# Altium schematic sheet Power management.SchDoc
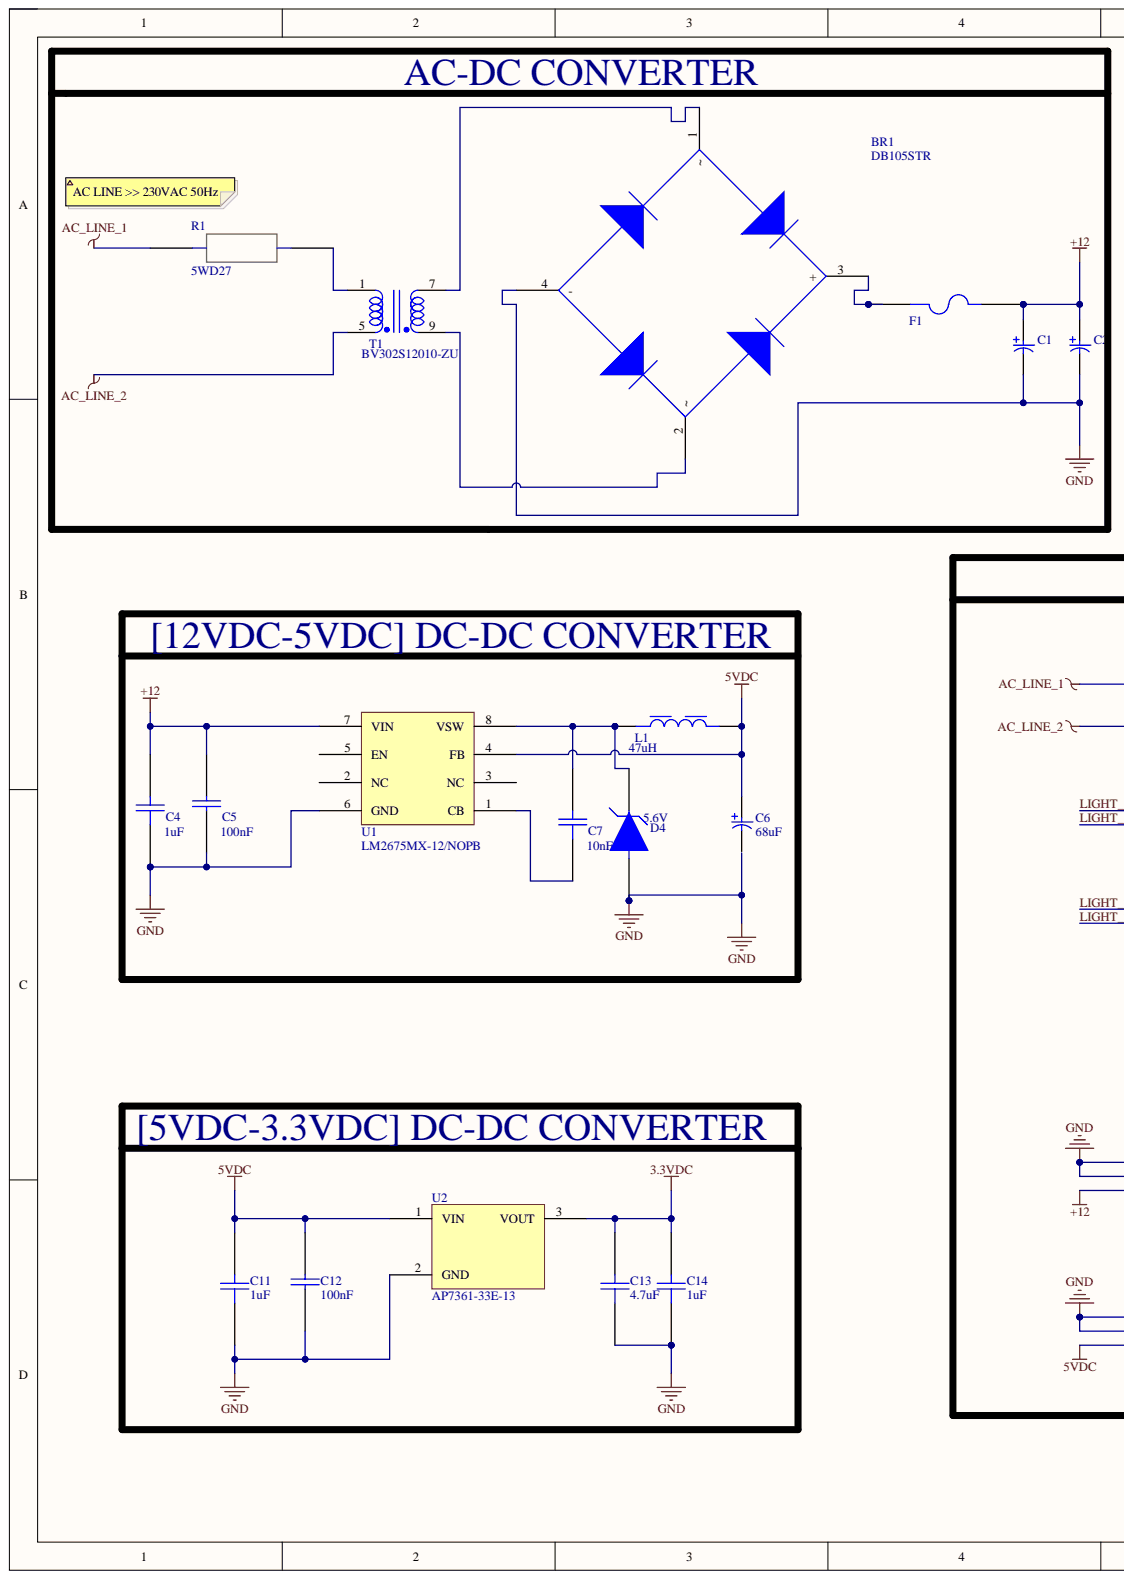
[diagram p0: Power management.SchDoc, left]
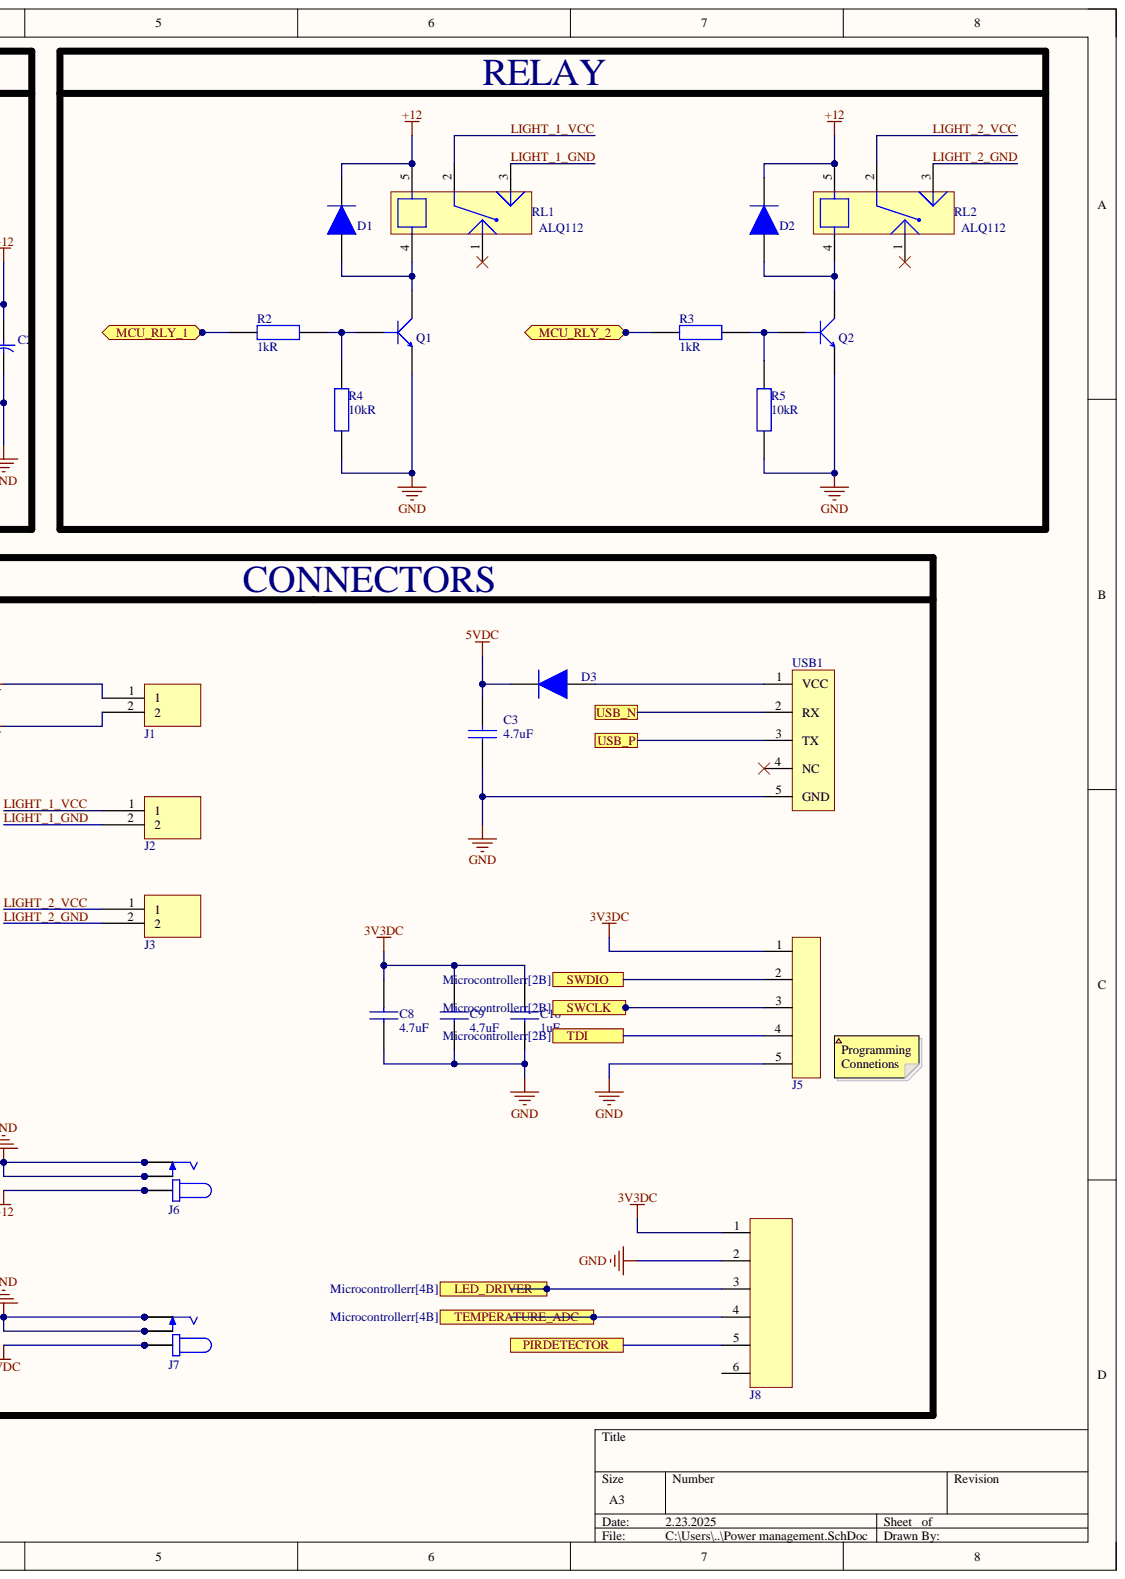
[diagram p1: Power management.SchDoc, right]

## Parts
BR1  DB105STR  [DB105STR]
C1  ESH477M050AH4AA  [CAP_RADIAL_D10_P5]  (value not drawn)
C2  ESH477M050AH4AA  [CAP_RADIAL_D10_P5]  (value not drawn)
C3  Capacitance: 4.7uF  [CAP_0805]  (hidden comment: CL21A475KAQNNNE)
C4  1uF  [CAP_0805]
C5  100nF  [CAP_0805]
C6  value 68uF  [EEV Series]  (hidden comment: EEV686M035A9HAA)
C7  10nF  [CAP_0603]
C8  Capacitance: 4.7uF  [CAP_0805]  (hidden comment: CL21A475KAQNNNE)
C9  Capacitance: 4.7uF  [CAP_0805]  (hidden comment: CL21A475KAQNNNE)
C10  Capacitance: 1uF  [CAP_0805]  (hidden comment: CL21B105KBFNNNE)
C11  value 1uF  [CAP_0805]  (hidden comment: CL21B105KBFNNNE)
C12  value 100nF  [CAP_0603]  (hidden comment: C0603C104K5RACTU)
C13  value 4.7uF  [CAP_0805]  (hidden comment: CL21A475KAQNNNE)
C14  value 1uF  [CAP_0805]  (hidden comment: CL21B105KBFNNNE)
D1  1N4148WS  [SOD323F]  (value not drawn)
D2  1N4148WS  [SOD323F]  (value not drawn)
D3  1N4148WS  [SOD323F]  (value not drawn)
D4  Reference Voltage-Nom: 5.6V  [SMB]  (hidden comment: 1SMB5919BT3G)
F1  3413.0216.22  [3413.0216.22]  (value not drawn)
J1  TBP01R1-508-02BE  [TBP01R1-508]  (value not drawn)
J2  TBP01R1-508-02BE  [TBP01R1-508]  (value not drawn)
J3  TBP01R1-508-02BE  [TBP01R1-508]  (value not drawn)
J5  SMH-105-02-L-S  [PINHEADER1X5_RA]  (value not drawn)
J6  PJ-037AH-SMT-TR  [JACK]  (value not drawn)
J7  PJ-037AH-SMT-TR  [JACK]  (value not drawn)
J8  64800611622  [64800611622]  (value not drawn)
L1  47uH  [IND_1212]
Q1  MMBT3904-7-F  [SOT-23F]  (value not drawn)
Q2  MMBT3904-7-F  [SOT-23F]  (value not drawn)
R1  5WD27  [RES_AXIAL_W]
R2  Resistance: 1kR  [RES_0603]  (hidden comment: RC0603FR-0710KL)
R3  Resistance: 1kR  [RES_0603]  (hidden comment: RC0603FR-0710KL)
R4  Resistance: 10kR  [RES_0603]  (hidden comment: RC0603FR-0710KL)
R5  Resistance: 10kR  [RES_0603]  (hidden comment: RC0603FR-0710KL)
RL1  ALQ112  [ALQ112]
RL2  ALQ112  [ALQ112]
T1  BV302S12010-ZU  [BV3025]
U1  LM2675MX-12/NOPB  [SOIC8]
U2  AP7361-33E-13  [SOT223]
USB1  10118192-0001LF  [MICRO_B_USB]  (value not drawn)

## Ports
LED_DRIVER, MCU_RLY_1, MCU_RLY_2, PIRDETECTOR, SWCLK, SWDIO, TDI, TEMPERATURE_ADC, USB_N, USB_P

## Nets
3.3VDC: C13.2 C14.2 U2.3
3V3DC: C8.2 C9.2 C10.2 J5.1 J8.1
5VDC: C3.2 C6.1 C11.2 C12.2 D3.1 J7.1A J7.1B L1.2 U1.4(FB) U2.1
+12: C1.1 C2.1 C4.2 C5.2 D1.1 D2.1 F1.2 J6.1A J6.1B RL1.5 RL2.5 U1.7(VIN)
AC_LINE_1: J1.1 R1.1
AC_LINE_2: J1.2 T1.5
GND: BR1.4(-) C1.2 C2.2 C3.1 C4.1 C5.1 C8.1 C9.1 C10.1 C11.1 C12.1 C13.1 C14.1 D4.C J5.5 J6.2A J6.2B J6.3A J6.3B J7.2A J7.2B J7.3A J7.3B J8.2 Q1.2 Q2.2 R4.1 R5.1 U1.6(GND) U2.2 USB1.5(GND)
LED_DRIVER: J8.3 ; port LED_DRIVER
LIGHT_1_GND: J2.2 RL1.3
LIGHT_1_VCC: J2.1 RL1.2
LIGHT_2_GND: J3.2 RL2.3
LIGHT_2_VCC: J3.1 RL2.2
MCU_RLY_1: R2.1 ; port MCU_RLY_1
MCU_RLY_2: R3.1 ; port MCU_RLY_2
PIRDETECTOR: J8.5 ; port PIRDETECTOR
SWCLK: J5.3 ; port SWCLK
SWDIO: J5.2 ; port SWDIO
TDI: J5.4 ; port TDI
TEMPERATURE_ADC: J8.4 ; port TEMPERATURE_ADC
USB_N: USB1.2(RX) ; port USB_N
USB_P: USB1.3(TX) ; port USB_P
NetBR1_1: BR1.1(~) T1.7
NetBR1_2: BR1.2(~) T1.9
NetBR1_3: BR1.3(+) F1.1
NetC7_1: C7.1 U1.1(CB)
NetC7_2: C7.2 D4.A L1.1 U1.8(VSW)
NetD1_2: D1.2 Q1.3 RL1.4
NetD2_2: D2.2 Q2.3 RL2.4
NetD3_2: D3.2 USB1.1(VCC)
NetQ1_1: Q1.1 R2.2 R4.2
NetQ2_1: Q2.1 R3.2 R5.2
NetR1_2: R1.2 T1.1

Not connected: C6 2; J8 6; RL1 1; RL2 1; U1 2 3(NC) 5(EN); USB1 4(NC)

## Library data of the parts
BR1 = DB105STR: Bridge Rect 1PHASE 600V 1A Db-s; Manufacturer: SMC; Designed by: ismet Akalın; Case/Package: DB105STR; Category: Industrial Control; Component Kind: Standard; ComponentLink1Description: http://www.smc-diodes.com/propdf/DB101S%20THRU%20DB107S%20N1459%20REV.B.pdf?src-supplier=Digikey; ComponentLink1URL: http://www.smc-diodes.com/propdf/DB101S%20THRU%20DB107S%20N1459%20REV.B.pdf?src-supplier=Digikey; Manufacturer Part Number: DB105STR; Max Current: 1A; Operating Voltage: 600V; Pricing: 1500=0,0542, 4500=0,0528, 7500=0,0521, 15000=0,0513, 30000=0,0499 (USD); RoHS: No; Supplier: Future Electronics; Supplier Part Number: 5119461; Library Name: firstpcblib.IntLib
C1 C2 = CAP ALUM 470 uF %20: CAP ALUM 470UF 20% 50V RADIAL TH; Manufacturer: KEMET; Capacitor Type: Aluminium; Designed by: ismet Akalın; Leakage Current: 235uA; Polarity: Polarized; Ripple Current: 630mA; Tan Delta: 0.12; Category: LDO; Component Kind: Standard; ComponentLink1Description: https://datasheet.ciiva.com:443/pdfs/VipMasterIC/IC/KEME/KEME-S-A0008512286/KEME-S-A0008512286-1.pdf?src-supplier=IHS+Markit; ComponentLink1URL: https://datasheet.ciiva.com:443/pdfs/VipMasterIC/IC/KEME/KEME-S-A0008512286/KEME-S-A0008512286-1.pdf?src-supplier=IHS+Markit; ComponentLink2Description: https://api.kemet.com/component-edge/download/datasheet/ESH477M050AH4AA.pdf?src-supplier=Digikey; ComponentLink2URL: https://api.kemet.com/component-edge/download/datasheet/ESH477M050AH4AA.pdf?src-supplier=Digikey; ComponentLink3Description: https://datasheet.octopart.com/ESH477M050AH4AA-KEMET-datasheet-130075338.pdf?src-supplier=Newark; ComponentLink3URL: https://datasheet.octopart.com/ESH477M050AH4AA-KEMET-datasheet-130075338.pdf?src-supplier=Newark; Dielectric Material: Aluminium; Manufacturer Part Number: ESH477M050AH4AA; Max Operating Temperature: 105°C; Min Operating Temperature: -40°C; Mounting Technology: Through Hole; Package Shape: Cylindrical; Packaging: Bulk; Pins: 2; Pricing: 1=0,61, 10=0,406, 50=0,3188, 200=0,2649, 600=0,2323, 1000=0,21949, 2600=0,19886, 5000=0,18688 (USD); Rated DC Voltage (URdc): 50V; Reach SVHC Compliant: Yes; RoHS: Yes; RoHS Compliant: Yes; Stock: 9084; Supplier: Digikey; Supplier Part Number: 399-15683-ND; Termination: Wire; Tolerance  (fill in as +-): 20%; Tolerance (fill in as +-): 20%; Value: 470uF; Library Name: firstpcblib.IntLib
C3 C8 C9 = CAP CER 4.7uF 0805: CAP CER 4.7uF %10 0805; Manufacturer: Samsung; Designed by: BERK; Dielectric: X5R; Tolerance: 10%; Voltage Rating: 25V; Case Code (Metric): 2012; Case Code (Imperial): 0805; Case/Package: 0805; Category: Ceramic Capacitors; Component Kind: Standard; ComponentLink1Description: https://datasheet.ciiva.com/25798/cl21a475kaqnnne-25798882.pdf?src-supplier=Digi-Key; ComponentLink1URL: https://datasheet.ciiva.com/25798/cl21a475kaqnnne-25798882.pdf?src-supplier=Digi-Key; Construction: Flat; Depth: 1.25mm; Height: 1.25mm; Lead Free: Lead Free; Length: 2mm; Library Name: UDEMY_Library.IntLib; Manufacturer Part Number: CL21A475KAQNNNE; Max Operating Temperature: 85°C; Min Operating Temperature: -55°C; Mount: Surface Mount; Package Quantity: 1; Packaging: Tape and Reel; Pricing 1: 1=0,11000, 10=0,08100, 50=0,04580, 100=0,03800, 500=0,02664, 1000=0,02205 (USD); Radiation Hardening: No; RoHS: Yes; RoHS Compliant: Yes; Series: CL; Stock 1: 1477018; Supplier 1: Digi-Key; Supplier Part Number 1: 1276-1244-1-ND; Thickness: 0.055inch; Voltage Rating (DC): 25V; Width: 0.049inch
C4 = CAP CER 1uF %10 0805: CAP CER 1uF %10 0805 -- CL21B105KBFNNNE; Manufacturer: Samsung; Capacitor Type: Ceramic; Designed by: ismet Akalın; Multilayer: Yes; Rated DC Voltage (URdc): 50V; Temperature Characteristics Code: X7R; Tolerance  (fill in as +-): 10%; Case/Package: 0805; Category: Ceramic Capacitors; Component Kind: Standard; ComponentLink1Description: https://datasheet.ciiva.com:443/pdfs/VipMasterIC/IC/SEMS/SEMS-S-A0008524728/SEMS-S-A0008523023-1.pdf?src-supplier=IHS+Markit; ComponentLink1URL: https://datasheet.ciiva.com:443/pdfs/VipMasterIC/IC/SEMS/SEMS-S-A0008524728/SEMS-S-A0008523023-1.pdf?src-supplier=IHS+Markit; ComponentLink2Description: https://datasheet.ciiva.com/16449/cl21b105kbfnnne-16449003.pdf?src-supplier=Future+Electronics; ComponentLink2URL: https://datasheet.ciiva.com/16449/cl21b105kbfnnne-16449003.pdf?src-supplier=Future+Electronics; ComponentLink3Description: https://datasheet.ciiva.com/25800/cl21b105kbfnnne-25800291.pdf?src-supplier=Digikey; ComponentLink3URL: https://datasheet.ciiva.com/25800/cl21b105kbfnnne-25800291.pdf?src-supplier=Digikey; Dielectric Material: Ceramic; Height: 1.35mm; JESD-609 Code: e3; Length: 2mm; Manufacturer Part Number: CL21B105KBFNNNE; Max Operating Temperature: 125°C; Min Operating Temperature: -55°C; Mounting Technology: Surface Mount; Package Shape: Rectangular; Package Style: SMTMeter; Packaging: Tape and Reel; Pins: 2; Pricing: 1=0,08, 10=0,027, 100=0,0216, 1000=0,0176 (USD); Reach SVHC Compliant: Yes; RoHS: Yes; RoHS Compliant: Yes; Stock: 542767; Supplier: Digikey; Supplier Part Number: 1276-1029-1-ND; Terminal Finish: Nickel; Termination: Wraparound; Tolerance (fill in as +-): 10%; Value: 1uF; Width: 1.25mm; Library Name: firstpcblib.IntLib
C5 = CAP CER 100nF %10 0805: CAP CER 100nF %10 0805 --CL21B104KBCNNNC; Manufacturer: Samsung; Capacitor Type: Ceramic; Designed by: ismet Akalın; Multilayer: Yes; Rated DC Voltage (URdc): 50V; Temperature Characteristics Code: X7R; Tolerance  (fill in as +-): 10%; Case/Package: 0805; Category: Ceramic Capacitors; Component Kind: Standard; ComponentLink1Description: https://datasheet.ciiva.com:443/pdfs/VipMasterIC/IC/SEMS/SEMS-S-A0008521003/SEMS-S-A0008521039-1.pdf?src-supplier=IHS+Markit; ComponentLink1URL: https://datasheet.ciiva.com:443/pdfs/VipMasterIC/IC/SEMS/SEMS-S-A0008521003/SEMS-S-A0008521039-1.pdf?src-supplier=IHS+Markit; ComponentLink2Description: https://datasheet.ciiva.com/25710/cl21b104kbcnnnc-25710182.pdf?src-supplier=Digikey; ComponentLink2URL: https://datasheet.ciiva.com/25710/cl21b104kbcnnnc-25710182.pdf?src-supplier=Digikey; ComponentLink3Description: https://datasheet.ciiva.com/29199/454152-da-01-en-vs-kondensator-0805-29199814.pdf?src-supplier=Conrad; ComponentLink3URL: https://datasheet.ciiva.com/29199/454152-da-01-en-vs-kondensator-0805-29199814.pdf?src-supplier=Conrad; Dielectric Material: Ceramic; Height: 0.95mm; JESD-609 Code: e3; Length: 2mm; Manufacturer Part Number: CL21B104KBCNNNC; Max Operating Temperature: 125°C; Min Operating Temperature: -55°C; Mounting Technology: Surface Mount; Package Shape: Rectangular; Package Style: SMTMeter; Packaging: Tape and Reel; Pins: 2; Pricing: 1=0,08, 10=0,01, 100=0,0088, 1000=0,0064 (USD); Reach SVHC Compliant: Yes; RoHS: Yes; RoHS Compliant: Yes; Stock: 684212; Supplier: Digikey; Supplier Part Number: 1276-1003-1-ND; Terminal Finish: Nickel; Termination: Wraparound; Tolerance (fill in as +-): 10%; Value: 100nF; Width: 1.25mm; Library Name: firstpcblib.IntLib
C6 = CAP ALUM 68uF %20 EEV Series: Manufacturer: KEMET; Capacitor Type: Aluminium; Designed by: ismet Akalın; ESR (Equivalent Series Resistance): 340mR; Leakage Current: 23.8uA; Polarity: Polarized; Rated DC Voltage (URdc): 35V; Ripple Current: 196mA; Tan Delta: 0.12; Tolerance  (fill in as +-): 20%; Case/Package: 2626; Category: Aluminum Electrolytic Capacitors; Component Kind: Standard; ComponentLink1Description: https://datasheet.ciiva.com:443/pdfs/VipMasterIC/IC/KEME/KEME-S-A0008611149/KEME-S-A0008611149-1.pdf?src-supplier=IHS+Markit; ComponentLink1URL: https://datasheet.ciiva.com:443/pdfs/VipMasterIC/IC/KEME/KEME-S-A0008611149/KEME-S-A0008611149-1.pdf?src-supplier=IHS+Markit; ComponentLink2Description: https://datasheet.ciiva.com/5365/kem-a4002-eev-535332-5365784.pdf?src-supplier=Mouser; ComponentLink2URL: https://datasheet.ciiva.com/5365/kem-a4002-eev-535332-5365784.pdf?src-supplier=Mouser; Description: CAP ALUM 68uF %20 EEV Series; Dielectric Material: Aluminium; Height: 7.7mm; Length: 7.8mm; Manufacturer Part Number: EEV686M035A9HAA; Max Operating Temperature: 105°C; Min Operating Temperature: -55°C; Mounting Technology: Surface Mount; Package Shape: Cylindrical; Package Style: SMTMeter; Packaging: Tape and Reel; Pins: 2; Pricing: 1=0,57, 10=0,377, 50=0,295, 100=0,268, 500=0,2189 (USD); Reach SVHC Compliant: Yes; RoHS: Yes; RoHS Compliant: Yes; Stock: 22607; Supplier: Digikey; Supplier Part Number: 399-6722-1-ND; Termination: Flat; Tolerance (fill in as +-): 20%; Width: 6.6mm; Library Name: firstpcblib.IntLib
C7 = CAP CER 10nF %10 0603: CAP CER 10nF %10 0603 0603B103K160CT; Manufacturer: Walsin Technologies; Capacitor Type: Ceramic; Designed by: ismet Akalın; Multilayer: Yes; Rated DC Voltage (URdc): 16V; Temperature Characteristics Code: X7R; Tolerance  (fill in as +-): 10%; Case/Package: 0603; Category: Ceramic Capacitors; Component Kind: Standard; ComponentLink1Description: https://datasheet.ciiva.com:443/pdfs/VipMasterIC/IC/WATC/WATC-S-A0009550342/WATC-S-A0009550342-1.pdf?src-supplier=IHS+Markit; ComponentLink1URL: https://datasheet.ciiva.com:443/pdfs/VipMasterIC/IC/WATC/WATC-S-A0009550342/WATC-S-A0009550342-1.pdf?src-supplier=IHS+Markit; ComponentLink2Description: http://www.farnell.com/datasheets/1958513.pdf?src-supplier=Farnell; ComponentLink2URL: http://www.farnell.com/datasheets/1958513.pdf?src-supplier=Farnell; ComponentLink3Description: https://datasheet.octopart.com/0603B103K160CT-Walsin-Technologies-datasheet-85392065.pdf?src-supplier=Element14; ComponentLink3URL: https://datasheet.octopart.com/0603B103K160CT-Walsin-Technologies-datasheet-85392065.pdf?src-supplier=Element14; ComponentLink4Description: http://www.passivecomponent.com/wp-content/uploads/2018/10/MLCC.pdf?src-supplier=Digikey; ComponentLink4URL: http://www.passivecomponent.com/wp-content/uploads/2018/10/MLCC.pdf?src-supplier=Digikey; ComponentLink5Description: https://datasheet.octopart.com/0603B103K160CT-Walsin-Technologies-datasheet-136037192.pdf?src-supplier=Future+Electronics; ComponentLink5URL: https://datasheet.octopart.com/0603B103K160CT-Walsin-Technologies-datasheet-136037192.pdf?src-supplier=Future+Electronics; ComponentLink6Description: https://datasheet.octopart.com/0603B103K160CT-Walsin-Technologies-datasheet-62066062.pdf?src-supplier=TME; ComponentLink6URL: https://datasheet.octopart.com/0603B103K160CT-Walsin-Technologies-datasheet-62066062.pdf?src-supplier=TME; Dielectric Material: Ceramic; Height: 0.8mm; JESD-609 Code: e3; Length: 1.6mm; Manufacturer Part Number: 0603B103K160CT; Max Operating Temperature: 125°C; Min Operating Temperature: -55°C; Mounting Technology: Surface Mount; Package Shape: Rectangular; Package Style: SMTMeter; Packaging: Tape and Reel; Pins: 2; Pricing: 1=0,1, 10=0,025, 100=0,0146, 1000=0,00737 (USD); Reach SVHC Compliant: Yes; RoHS: Yes; RoHS Compliant: Yes; Stock: 40006; Supplier: Digikey; Supplier Part Number: 1292-1384-1-ND; Terminal Finish: Nickel; Termination: Wraparound; Tolerance (fill in as +-): 10%; Value: 10nF; Width: 0.8mm; Library Name: firstpcblib.IntLib
C10 = CAP CER 1uF 0805: CAP CER 1uF %10 0805; Manufacturer: Samsung; Designed by: BERK; Dielectric: X7R; Tolerance: 10%; Voltage: 50V; Case Code (Metric): 2012; Case Code (Imperial): 0805; Case/Package: 0805; Category: Ceramic Capacitors; Component Kind: Standard; ComponentLink1Description: https://datasheet.ciiva.com/25800/cl21b105kbfnnne-25800291.pdf?src-supplier=Digi-Key; ComponentLink1URL: https://datasheet.ciiva.com/25800/cl21b105kbfnnne-25800291.pdf?src-supplier=Digi-Key; ComponentLink2Description: https://datasheet.ciiva.com/16449/cl21b105kbfnnne-16449003.pdf?src-supplier=Future+Electronics; ComponentLink2URL: https://datasheet.ciiva.com/16449/cl21b105kbfnnne-16449003.pdf?src-supplier=Future+Electronics; ComponentLink3Description: https://datasheet.ciiva.com/26838/getdatasheetpartid-354075-26838668.pdf?src-supplier=Verical; ComponentLink3URL: https://datasheet.ciiva.com/26838/getdatasheetpartid-354075-26838668.pdf?src-supplier=Verical; Construction: Flat; Depth: 1.25mm; Height: 1.25mm; Length: 2mm; Library Name: UDEMY_Library.IntLib; Manufacturer Part Number: CL21B105KBFNNNE; Max Operating Temperature: 125°C; Min Operating Temperature: -55°C; Mount: Surface Mount; Package Quantity: 1; Packaging: Tape and Reel; Pricing 1: 2000=0,02138, 4000=0,01956 (USD); Radiation Hardening: No; RoHS: Yes; RoHS Compliant: Yes; Series: CL; Stock 1: 958000; Supplier 1: Digi-Key; Supplier Part Number 1: 1276-1029-2-ND; Thickness: 0.053inch; Voltage Rating: 50V; Width: 0.049inch
C11 C14 = CAP CER 1uF %10 0805: Manufacturer: Samsung; Capacitor Type: Ceramic; Designed by: ismet Akalın; Multilayer: Yes; Rated DC Voltage (URdc): 50V; Temperature Characteristics Code: X7R; Tolerance  (fill in as +-): 10%; Case/Package: 0805; Category: Ceramic Capacitors; Component Kind: Standard; ComponentLink1Description: https://datasheet.ciiva.com:443/pdfs/VipMasterIC/IC/SEMS/SEMS-S-A0008524728/SEMS-S-A0008523023-1.pdf?src-supplier=IHS+Markit; ComponentLink1URL: https://datasheet.ciiva.com:443/pdfs/VipMasterIC/IC/SEMS/SEMS-S-A0008524728/SEMS-S-A0008523023-1.pdf?src-supplier=IHS+Markit; ComponentLink2Description: https://datasheet.ciiva.com/16449/cl21b105kbfnnne-16449003.pdf?src-supplier=Future+Electronics; ComponentLink2URL: https://datasheet.ciiva.com/16449/cl21b105kbfnnne-16449003.pdf?src-supplier=Future+Electronics; ComponentLink3Description: https://datasheet.ciiva.com/25800/cl21b105kbfnnne-25800291.pdf?src-supplier=Digikey; ComponentLink3URL: https://datasheet.ciiva.com/25800/cl21b105kbfnnne-25800291.pdf?src-supplier=Digikey; Description: CAP CER 1uF %10 0805; Dielectric Material: Ceramic; Height: 1.35mm; JESD-609 Code: e3; Length: 2mm; Manufacturer Part Number: CL21B105KBFNNNE; Max Operating Temperature: 125°C; Min Operating Temperature: -55°C; Mounting Technology: Surface Mount; Package Shape: Rectangular; Package Style: SMTMeter; Packaging: Tape and Reel; Pins: 2; Pricing: 1=0,08, 10=0,027, 100=0,0216, 1000=0,0176 (USD); Reach SVHC Compliant: Yes; RoHS: Yes; RoHS Compliant: Yes; Stock: 542767; Supplier: Digikey; Supplier Part Number: 1276-1029-1-ND; Terminal Finish: Nickel; Termination: Wraparound; Tolerance (fill in as +-): 10%; Width: 1.25mm; Library Name: firstpcblib.IntLib
C12 = CAP CER 100nF 0603: CAP CER 100nF %10 0603 SMD; Manufacturer: KEMET; Capacitor Type: Ceramic; Designed by: ismet Akalın; Multilayer: Yes; Rated DC Voltage (URdc): 50V; Temperature Characteristics Code: X7R; Tolerance  (fill in as +-): 10%; Case/Package: 0603; Category: Ceramic Capacitors; Component Kind: Standard; ComponentLink1Description: https://datasheet.ciiva.com:443/pdfs/VipMasterIC/IC/KEME/KEME-S-A0008991654/KEME-S-A0008991654-1.pdf?src-supplier=IHS+Markit; ComponentLink1URL: https://datasheet.ciiva.com:443/pdfs/VipMasterIC/IC/KEME/KEME-S-A0008991654/KEME-S-A0008991654-1.pdf?src-supplier=IHS+Markit; ComponentLink2Description: https://datasheet.ciiva.com/7823/kem-c1002-x7r-smd-7823591.pdf?src-supplier=Element14; ComponentLink2URL: https://datasheet.ciiva.com/7823/kem-c1002-x7r-smd-7823591.pdf?src-supplier=Element14; ComponentLink3Description: https://datasheet.ciiva.com/14602/70095383-14602583.pdf?src-supplier=Allied+Electronics; ComponentLink3URL: https://datasheet.ciiva.com/14602/70095383-14602583.pdf?src-supplier=Allied+Electronics; Dielectric Material: Ceramic; Height: 0.8mm; JESD-609 Code: e3; Length: 1.6mm; Manufacturer Part Number: C0603C104K5RACTU; Max Operating Temperature: 125°C; Min Operating Temperature: -55°C; Mounting Technology: Surface Mount; Package Shape: Rectangular; Package Style: SMTMeter; Packaging: Tape and Reel; Pins: 2; Pricing: 1=0,08, 10=0,02, 100=0,016, 1000=0,0128 (USD); Reach SVHC Compliant: Yes; RoHS: Yes; RoHS Compliant: Yes; Supplier: Digikey; Supplier Part Number: 399-C0603C104K5RACTUDKR-ND; Terminal Finish: Nickel; Termination: Wraparound; Tolerance (fill in as +-): 10%; Width: 0.8mm; Library Name: firstpcblib.IntLib
C13 = CAP CER 4.7uF %10 0805: Manufacturer: Samsung; Capacitor Type: Ceramic; Designed by: ismet Akalın; Multilayer: Yes; Rated DC Voltage (URdc): 25V; Temperature Characteristics Code: X5R; Tolerance  (fill in as +-): 10%; Case/Package: 0805; Category: Ceramic Capacitors; Component Kind: Standard; ComponentLink1Description: https://datasheet.ciiva.com:443/pdfs/VipMasterIC/IC/SEMS/SEMS-S-A0008528919/SEMS-S-A0008528938-1.pdf?src-supplier=IHS+Markit; ComponentLink1URL: https://datasheet.ciiva.com:443/pdfs/VipMasterIC/IC/SEMS/SEMS-S-A0008528919/SEMS-S-A0008528938-1.pdf?src-supplier=IHS+Markit; ComponentLink2Description: https://datasheet.ciiva.com/25798/cl21a475kaqnnne-25798882.pdf?src-supplier=Digikey; ComponentLink2URL: https://datasheet.ciiva.com/25798/cl21a475kaqnnne-25798882.pdf?src-supplier=Digikey; Description: CAP CER 4.7uF %10 0805; Dielectric Material: Ceramic; Height: 1.4mm; JESD-609 Code: e3; Length: 2mm; Manufacturer Part Number: CL21A475KAQNNNE; Max Operating Temperature: 85°C; Min Operating Temperature: -55°C; Mounting Technology: Surface Mount; Package Shape: Rectangular; Package Style: SMTMeter; Packaging: Tape and Reel; Pins: 2; Pricing: 1=0,1, 10=0,052, 100=0,0335, 1000=0,02212 (USD); Reach SVHC Compliant: Yes; RoHS: Yes; RoHS Compliant: Yes; Stock: 2687030; Supplier: Digikey; Supplier Part Number: 1276-1244-1-ND; Terminal Finish: Nickel; Termination: Wraparound; Tolerance (fill in as +-): 10%; Width: 1.25mm; Library Name: firstpcblib.IntLib
D1-D3 = 1N4148WS: Diode, Single, 75 V, 150 mA, 1 V, 4 ns; Manufacturer: ON Semiconductor / Fairchild; Average Rectified Current: 150mA; Designed by: BERK; Forward Voltage: 1V; Max Forward Surge Current (Ifsm): 2A; Max Repetitive Reverse Voltage (Vrrm): 75V; Max Reverse Current: 5uA; Power Dissipation: 200mW; Recovery Time: 4ns; Category: Rectifier Diodes; Component Kind: Standard; ComponentLink1Description: https://datasheet.ciiva.com/25968/1n914bws-25968945.pdf?src-supplier=Avnet+Europe; ComponentLink1URL: https://datasheet.ciiva.com/25968/1n914bws-25968945.pdf?src-supplier=Avnet+Europe; ComponentLink2Description: https://datasheet.ciiva.com/30479/0900766b810e1cd3-30479206.pdf?src-supplier=RSComponents; ComponentLink2URL: https://datasheet.ciiva.com/30479/0900766b810e1cd3-30479206.pdf?src-supplier=RSComponents; ComponentLink3Description: https://datasheet.ciiva.com/910/1n4148ws-910381.pdf?src-supplier=Digi-Key; ComponentLink3URL: https://datasheet.ciiva.com/910/1n4148ws-910381.pdf?src-supplier=Digi-Key; ComponentLink4Description: https://datasheet.ciiva.com/15208/getdatasheetpartid-127819-15208358.pdf?src-supplier=Verical; ComponentLink4URL: https://datasheet.ciiva.com/15208/getdatasheetpartid-127819-15208358.pdf?src-supplier=Verical; ComponentLink5Description: https://datasheet.ciiva.com/8300/1n914bws-8300360.pdf?src-supplier=Avnet; ComponentLink5URL: https://datasheet.ciiva.com/8300/1n914bws-8300360.pdf?src-supplier=Avnet; ComponentLink6Description: https://datasheet.ciiva.com/910/1n4148ws-910380.pdf?src-supplier=Digi-Key; ComponentLink6URL: https://datasheet.ciiva.com/910/1n4148ws-910380.pdf?src-supplier=Digi-Key; ComponentLink7Description: https://datasheet.ciiva.com/910/1n4148ws-910382.pdf?src-supplier=Digi-Key; ComponentLink7URL: https://datasheet.ciiva.com/910/1n4148ws-910382.pdf?src-supplier=Digi-Key; Contact Plating: Tin,Matte; Element Configuration: Single; Forward Current: 150mA; Height: 1mm; Lead Free: Lead Free; Length: 1.8mm; Library Name: UDEMY_Library.IntLib; Manufacturer Part Number: 1N4148WS; Max Operating Temperature: 150°C; Max Reverse Voltage (DC): 75V; Min Operating Temperature: -55°C; Mount: Surface Mount; Number of Pins: 2; Package Quantity: 1; Packaging: Tape and Reel; Pricing 1: 1=0,17000, 10=0,12100, 100=0,06530, 500=0,05128, 1000=0,03562 (USD); Radiation Hardening: No; REACH SVHC: No SVHC; Reverse Recovery Time: 4ns; Reverse Voltage: 75V; RoHS: Yes; RoHS Compliant: Yes; Series: -; Stock 1: 79118; Supplier 1: Digi-Key; Supplier Part Number 1: 1N4148WSFSCT-ND; Weight: 0.0276g; Width: 1.35mm
D4 = 1SMB5919BT3G: Zener Diode, 5.6V V(Z), 5%, 0.55W, Silicon, Unidirectional, DO-214AA; Manufacturer: ON Semiconductor; Designed by: ismet Akalın; Dynamic Impedance-Max: 2R; Max Power Dissipation: 550mW; Number of Elements: 1; Package Body Material: Plastic; Qualification Status: Not Qualified; Test Current: 66.9mA; Time @ Peak Reflow Temperature-Max (s): 40s; Category: Zener Diodes; Component Kind: Standard; ComponentLink1Description: https://datasheet.ciiva.com:443/pdfs/VipMasterIC/IC/ONSM/ONSM-S-A0001810303/ONSM-S-A0001810303-1.pdf?src-supplier=IHS+Markit; ComponentLink1URL: https://datasheet.ciiva.com:443/pdfs/VipMasterIC/IC/ONSM/ONSM-S-A0001810303/ONSM-S-A0001810303-1.pdf?src-supplier=IHS+Markit; ComponentLink2Description: https://datasheet.ciiva.com/895/1smb5913bt3-d-895203.pdf?src-supplier=Digikey; ComponentLink2URL: https://datasheet.ciiva.com/895/1smb5913bt3-d-895203.pdf?src-supplier=Digikey; ComponentLink3Description: https://datasheet.ciiva.com/895/1smb5913bt3-d-895204.pdf?src-supplier=Digikey; ComponentLink3URL: https://datasheet.ciiva.com/895/1smb5913bt3-d-895204.pdf?src-supplier=Digikey; ComponentLink4Description: https://datasheet.ciiva.com/895/1smb5913bt3-d-895205.pdf?src-supplier=Digikey; ComponentLink4URL: https://datasheet.ciiva.com/895/1smb5913bt3-d-895205.pdf?src-supplier=Digikey; Diode Element Material: SILICON; Diode Type: ZENER; JEDEC-95 Code: DO-214AA; JESD-609 Code: e3; Manufacturer Part Number: 1SMB5919BT3G; Max Operating Temperature: 150°C; Min Operating Temperature: -65°C; Moisture Sensitivity Level: 1; Package Shape: Rectangular; Package Style: SMALL OUTLINEMeter; Peak Reflow Temperature (Cel): 260°C; Pins: 2; Polarity: Unidirectional; Pricing: 2500=0,0735 (USD); Reach SVHC Compliant: No; RoHS: Yes; RoHS Compliant: Yes; Stock: 2500; Supplier: Digikey; Supplier Part Number: 1SMB5919BT3GOSTR-ND; Surface Mount: Yes; Technology: ZENER; Terminal Finish: Tin; Terminal Form: L BEND; Terminal Position: DUAL; Voltage Tol-Max: 5%; Library Name: firstpcblib.IntLib
F1 = 3413.0216.22: Electric Fuse, Very Fast Blow, 1A, 32VAC, 63VDC, 63A (IR), Surface Mount, 1206; Manufacturer: Schurter; Body Breadth: 1.6mm; Body Height: 0.6mm; Body Length or Diameter: 3.2mm; Designed by: ismet Akalın; Joule-integral-Nom: 0.2J; Pre-arcing time-Min: 1ms; Reference Standard: UL; Voltage Rating: 32V; Blow Characteristic: VERY FAST; Category: Fuses; Circuit Protection Type: Electric Fuse; Component Kind: Standard; ComponentLink1Description: https://datasheet.ciiva.com:443/pdfs/VipMasterIC/IC/SHRI/SHRI-S-A0004985783/SHRI-S-A0004985783-1.pdf?src-supplier=IHS+Markit; ComponentLink1URL: https://datasheet.ciiva.com:443/pdfs/VipMasterIC/IC/SHRI/SHRI-S-A0004985783/SHRI-S-A0004985783-1.pdf?src-supplier=IHS+Markit; ComponentLink2Description: https://datasheet.ciiva.com/301/typ-usi-1206-301440.pdf?src-supplier=Digikey; ComponentLink2URL: https://datasheet.ciiva.com/301/typ-usi-1206-301440.pdf?src-supplier=Digikey; ComponentLink3Description: https://datasheet.ciiva.com/14102/qousi1206-data-e-14102903.pdf?src-supplier=Distrelec; ComponentLink3URL: https://datasheet.ciiva.com/14102/qousi1206-data-e-14102903.pdf?src-supplier=Distrelec; ComponentLink4Description: https://datasheet.ciiva.com/8264/typ-usi-1206-8264583.pdf?src-supplier=Avnet; ComponentLink4URL: https://datasheet.ciiva.com/8264/typ-usi-1206-8264583.pdf?src-supplier=Avnet; ComponentLink5Description: https://datasheet.ciiva.com/16316/typ-usi-1206-16316588.pdf?src-supplier=Future+Electronics; ComponentLink5URL: https://datasheet.ciiva.com/16316/typ-usi-1206-16316588.pdf?src-supplier=Future+Electronics; ComponentLink6Description: https://datasheet.ciiva.com/301/typ-usi-1206-301439.pdf?src-supplier=Digikey; ComponentLink6URL: https://datasheet.ciiva.com/301/typ-usi-1206-301439.pdf?src-supplier=Digikey; ComponentLink7Description: https://datasheet.ciiva.com/301/typ-usi-1206-301441.pdf?src-supplier=Digikey; ComponentLink7URL: https://datasheet.ciiva.com/301/typ-usi-1206-301441.pdf?src-supplier=Digikey; ComponentLink8Description: https://datasheet.ciiva.com/14541/70160006-14541114.pdf?src-supplier=Allied+Electronics; ComponentLink8URL: https://datasheet.ciiva.com/14541/70160006-14541114.pdf?src-supplier=Allied+Electronics; Current Rating: 1A; JESD-609 Code: e4; Manufacturer Part Number: 3413.0216.22; Max Operating Temperature: 90°C; Min Operating Temperature: -55°C; Mounting Technology: Surface Mount; Packaging: Tape and Reel; Pricing: 1=0,67, 50=0,524, 100=0,397, 500=0,3625 (USD); Rated Breaking Capacity: 63A; Reach SVHC Compliant: Yes; RoHS: Yes; RoHS Compliant: Yes; Stock: 13626; Supplier: Digikey; Supplier Part Number: 486-1144-1-ND; Terminal Finish: Nickel; Termination: Wraparound; Library Name: firstpcblib.IntLib
J1-J3 = TBP01R1-508-02BE: Strip Terminal Block; Manufacturer: CUI Devices; Designed by: ismet Akalın; Category: Terminal Blocks; Component Kind: Standard; ComponentLink1Description: https://www.cuidevices.com/product/resource/digikeypdf/tbp01r1-508.pdf?src-supplier=Digikey; ComponentLink1URL: https://www.cuidevices.com/product/resource/digikeypdf/tbp01r1-508.pdf?src-supplier=Digikey; ComponentLink2Description: https://datasheet.octopart.com/TBP01R1-508-02BE-CUI-Devices-datasheet-147843976.pdf?src-supplier=Future+Electronics; ComponentLink2URL: https://datasheet.octopart.com/TBP01R1-508-02BE-CUI-Devices-datasheet-147843976.pdf?src-supplier=Future+Electronics; ComponentLink3Description: https://datasheet.octopart.com/TBP01R1-508-02BE-CUI-Devices-datasheet-154730754.pdf?src-supplier=Electro+Sonic; ComponentLink3URL: https://datasheet.octopart.com/TBP01R1-508-02BE-CUI-Devices-datasheet-154730754.pdf?src-supplier=Electro+Sonic; Manufacturer Part Number: TBP01R1-508-02BE; Pricing: 1=0,22, 10=0,17, 25=0,1496, 50=0,1346, 100=0,1213, 400=0,0995, 800=0,09115, 1200=0,08698, 5200=0,0775 (USD); RoHS: Yes; Stock: 91901; Supplier: Digikey; Supplier Part Number: 102-6406-ND; Library Name: firstpcblib.IntLib
J5 = SMH-105-02-L-S: .100 C.L. HORIZONTAL SMT SOCKET; Manufacturer: Samtec; Contact Resistance: 10mR; Designed by: BERK; Gender: Receptacle; Number of Contacts: 4; Pitch: 2.54mm; Category: Headers and Wire Housings; Component Kind: Standard; ComponentLink1Description: http://components.arrow.com/part/dataview/0323_04802604?src-supplier=Arrow+Europe; ComponentLink1URL: http://components.arrow.com/part/dataview/0323_04802604?src-supplier=Arrow+Europe; ComponentLink2Description: http://www.farnell.com/datasheets/1927708.pdf?_ga=2.237598468.569325269.1503285096-1413671594.1503025027&src-supplier=Farnell; ComponentLink2URL: http://www.farnell.com/datasheets/1927708.pdf?_ga=2.237598468.569325269.1503285096-1413671594.1503025027&src-supplier=Farnell; ComponentLink3Description: ftp://ftp.samtec.com/webfiles/PDF/SMH.PDF?src-supplier=Avnet+Europe; ComponentLink3URL: ftp://ftp.samtec.com/webfiles/PDF/SMH.PDF?src-supplier=Avnet+Europe; ComponentLink4Description: http://www.samtec.com/documents/webfiles/pdf/SMH.PDF?src-supplier=Newark; ComponentLink4URL: http://www.samtec.com/documents/webfiles/pdf/SMH.PDF?src-supplier=Newark; ComponentLink5Description: http://www.samtec.com/documents/webfiles/pdf/SMH.PDF?src-supplier=Avnet; ComponentLink5URL: http://www.samtec.com/documents/webfiles/pdf/SMH.PDF?src-supplier=Avnet; ComponentLink6Description: http://suddendocs.samtec.com/catalog_english/smh.pdf?src-supplier=Digi-Key; ComponentLink6URL: http://suddendocs.samtec.com/catalog_english/smh.pdf?src-supplier=Digi-Key; Contact Material: Bronze; Contact Plating: Gold; Depth: 10.8mm; Housing Color: Black; Housing Material: Polymer; Length: 13.21mm; Library Name: UDEMY_Library.IntLib; Manufacturer Part Number: SMH-105-02-L-S; Max Operating Temperature: 125°C; Min Operating Temperature: -55°C; Number of Rows: 1; Orientation: Horizontal; Packaging: Rail/Tube; Pricing 1: 1=1,40000, 10=1,27400, 100=1,09200, 500=0,91000, 1000=0,78000, 5000=0,69160, 10000=0,67600 (USD); Radiation Hardening: No; REACH SVHC: No SVHC; RoHS: Yes; Stock 1: 59; Supplier 1: Digi-Key; Supplier Part Number 1: SAM11813-ND; Termination: Solder
J6 J7 = PJ-037AH-SMT-TR: Power Barrel Connector Jack 2.10mm ID (0.083"), 5.50mm OD (0.217") Surface Mount, Right Angle; Through Hole; Manufacturer: CUI; Designed by: ismet Akalın; Category: Uncategorized; Component Kind: Standard; Manufacturer Part Number: PJ-037AH-SMT-TR; Library Name: firstpcblib.IntLib
J8 = 64800611622_conn_6pin: 1.50mm 6PIN Connector; Manufacturer: Wurth Electronics; Component Kind: Standard; Library Name: UDEMY_Library.IntLib; Manufacturer Part Number: 64800611622; Pricing 1: 1000=0,29900, 2000=0,28200 (GBP); RoHS: No; Stock 1: 100; Supplier 1: RSComponents; Supplier Part Number 1: 2138726
L1 = 78438335470: FIXED IND 47UH 390MA 2.3 OHM SMD 78438335470; Manufacturer: Wurth Electronics; Designed by: ismet Akalın; Inductor Type: GENERAL PURPOSE INDUCTOR; Category: Fixed Inductors; Component Kind: Standard; ComponentLink1Description: https://datasheet.ciiva.com:443/pdfs/VipMasterIC/IC/MIDC/MIDC-S-A0004708317/MIDC-S-A0004708317-1.pdf?src-supplier=IHS+Markit; ComponentLink1URL: https://datasheet.ciiva.com:443/pdfs/VipMasterIC/IC/MIDC/MIDC-S-A0004708317/MIDC-S-A0004708317-1.pdf?src-supplier=IHS+Markit; ComponentLink2Description: https://media.digikey.com/pdf/Data%20Sheets/Wurth%20Electronics%20PDFs/Q+_Products_Flyer.pdf?src-supplier=Digikey; ComponentLink2URL: https://media.digikey.com/pdf/Data%20Sheets/Wurth%20Electronics%20PDFs/Q+_Products_Flyer.pdf?src-supplier=Digikey; Manufacturer Part Number: 78438335470; Pricing: 1=1,9, 50=1,773, 250=1,62272, 500=1,5048 (USD); Reach SVHC Compliant: Yes; RoHS: Yes; RoHS Compliant: No; Stock: 5404; Supplier: Digikey; Supplier Part Number: 732-6947-1-ND; Library Name: firstpcblib.IntLib
Q1 Q2 = MMBT3904-7-F: Transistor General Purpose BJT NPN 40 Volt 0.2A Automotive 3-Pin SOT-23; Manufacturer: Diodes Zetex; Collector Base Voltage (VCBO): 60V; Collector Emitter Breakdown Voltage: 40V; Collector Emitter Saturation Voltage: 300mV; Continuous Collector Current: 200mA; Designed by: BERK; Element Configuration: Single; Emitter Base Voltage (VEBO): 6V; Frequency: 300MHz; hFE Min: 100; Max Power Dissipation: 300mW; Number of Elements: 1; Power Dissipation: 350mW; Case/Package: SOT-23; Category: BJTs; Collector Emitter Voltage (VCEO): 40V; Collector-emitter Voltage-Max: 300mV; Component Kind: Standard; ComponentLink1Description: https://datasheet.ciiva.com/11201/ds30036-11201778.pdf?src-supplier=Avnet; ComponentLink1URL: https://datasheet.ciiva.com/11201/ds30036-11201778.pdf?src-supplier=Avnet; ComponentLink2Description: https://datasheet.ciiva.com/15138/getdatasheetpartid-53265-15138780.pdf?src-supplier=Verical; ComponentLink2URL: https://datasheet.ciiva.com/15138/getdatasheetpartid-53265-15138780.pdf?src-supplier=Verical; ComponentLink3Description: https://datasheet.ciiva.com/6361/ds30036-6361254.pdf?src-supplier=Digi-Key; ComponentLink3URL: https://datasheet.ciiva.com/6361/ds30036-6361254.pdf?src-supplier=Digi-Key; ComponentLink4Description: https://datasheet.ciiva.com/22711/1915516-22711750.pdf?src-supplier=Element14; ComponentLink4URL: https://datasheet.ciiva.com/22711/1915516-22711750.pdf?src-supplier=Element14; ComponentLink5Description: https://datasheet.ciiva.com/11198/ds30036-11198999.pdf?src-supplier=Avnet; ComponentLink5URL: https://datasheet.ciiva.com/11198/ds30036-11198999.pdf?src-supplier=Avnet; ComponentLink6Description: https://datasheet.ciiva.com/6361/ds30036-6361256.pdf?src-supplier=Digi-Key; ComponentLink6URL: https://datasheet.ciiva.com/6361/ds30036-6361256.pdf?src-supplier=Digi-Key; Contact Plating: Tin,Matte; Gain Bandwidth Product: 300MHz; Height: 1mm; Lead Free: Lead Free; Length: 3.05mm; Library Name: UDEMY_Library.IntLib; Manufacturer Part Number: MMBT3904-7-F; Max Breakdown Voltage: 40V; Max Collector Current: 200mA; Max Frequency: 300MHz; Max Operating Temperature: 150°C; Min Operating Temperature: -55°C; Mount: Surface Mount; Number of Pins: 3; Package Quantity: 3000; Packaging: Tape and Reel; Polarity: NPN; Pricing 1: 1=0,12000, 10=0,08600, 25=0,08280, 100=0,04660, 500=0,03664, 1000=0,02544 (USD); Radiation Hardening: No; REACH SVHC: No SVHC; RoHS: Yes; RoHS Compliant: Yes; Series: -; Stock 1: 2393281; Supplier 1: Digi-Key; Supplier Part Number 1: MMBT3904-FDIDKR-ND; Transition Frequency: 300MHz; Voltage Rating (DC): 40V; Weight: 0.000282oz; Width: 1.4mm
R1 = 5WD27: Fixed Resistor, Wire Wound, 5W, 0.27ohm, 200V, 5% +/-Tol, 300ppm/Cel, 8738; Manufacturer: NTE Electronics; Designed by: ismet Akalın; Lead Diameter: 0.8mm; Lead Length: 38.1mm; Package Height: 9.65mm; Package Length: 22mm; Temperature Coefficient (ppm/Cel): 300; Value: 270mR; Case/Package: 8738; Category: Through-Hole Resistors; Component Kind: Standard; Construction: Wirewound; Manufacturer Part Number: 5WD27; Package Style: AXIAL; Package Width: 9.65mm; Pins: 2; Power: 5W; Resistor Type: FIXED RESISTOR; RoHS Compliant: Yes; Technology: WIRE WOUND; Tolerance: 5%; Working Voltage: 200V; Library Name: firstpcblib.IntLib
R2 R3 = RES 1K OHM 0603: RES 1K %1 OHM 0603 SMD; Manufacturer: Yageo; Manufacturer Part Number: RC0603JR-071KL; Body Length: 1.6mm; Designed by: BERK; Power Rating: 100mW; Resistance Tolerance: 5%; Temperature Coefficient: 100ppm/°C; Voltage Rating: 50V; Case Code (Metric): 1608; Case Code (Imperial): 0603; Case/Package: 0603; Category: Chip SMD Resistors; Component Kind: Standard; ComponentLink1Description: https://datasheet.ciiva.com/8883/pyu-rc0603-51-rohs-l-4-8883051.pdf?src-supplier=Avnet; ComponentLink1URL: https://datasheet.ciiva.com/8883/pyu-rc0603-51-rohs-l-4-8883051.pdf?src-supplier=Avnet; ComponentLink2Description: https://datasheet.ciiva.com/7746/443971-7746461.pdf?src-supplier=Element14; ComponentLink2URL: https://datasheet.ciiva.com/7746/443971-7746461.pdf?src-supplier=Element14; ComponentLink3Description: https://datasheet.ciiva.com/22747/443971-22747533.pdf?src-supplier=Element14; ComponentLink3URL: https://datasheet.ciiva.com/22747/443971-22747533.pdf?src-supplier=Element14; ComponentLink4Description: https://datasheet.ciiva.com/7746/443971-7746462.pdf?src-supplier=Element14; ComponentLink4URL: https://datasheet.ciiva.com/7746/443971-7746462.pdf?src-supplier=Element14; Construction: Rectangular; Contact Plating: Tin,Matte; Depth: 0.8mm; Height: 0.45mm; Lead Free: Lead Free; Length: 1.6mm; Library Name: UDEMY_Library.IntLib; Max Operating Temperature: 155°C; Military Standard: Not; Min Operating Temperature: -55°C; Number of Pins: 2; Package Quantity: 5000; Packaging: Tape and Reel; Pricing 1: 1=0,10000, 10=0,01900, 100=0,00770, 1000=0,00344, 2500=0,00298 (USD); Radiation Hardening: No; REACH SVHC: Unknown; Resistor Type: Thick Film; RoHS: Yes; RoHS Compliant: Yes; Series: RC; Size Code: 0603; Stock 1: 5112056; Supplier 1: Digi-Key; Supplier Part Number 1: 311-1.0KGRCT-ND; Termination: SMD/SMT; Tolerance: 5%; Voltage Rating (DC): 50V; Width: 0.8mm
R4 R5 = RES 10K OHM 0603: RES 10K %1 OHM 0603 SMD; Manufacturer: Yageo; Designed by: BERK; Max Power Dissipation: 100mW; Resistance Tolerance: 1%; Temperature Coefficient: 100ppm/°C; Voltage Rating: 75V; Voltage Rating (DC): 50V; Case Code (Metric): 1608; Case Code (Imperial): 0603; Case/Package: 0603; Category: Chip SMD Resistors; Component Kind: Standard; ComponentLink1Description: https://datasheet.ciiva.com/7660/38590-7660789.pdf?src-supplier=Element14; ComponentLink1URL: https://datasheet.ciiva.com/7660/38590-7660789.pdf?src-supplier=Element14; ComponentLink2Description: https://datasheet.ciiva.com/15189/getdatasheetpartid-409289-15189591.pdf?src-supplier=Verical; ComponentLink2URL: https://datasheet.ciiva.com/15189/getdatasheetpartid-409289-15189591.pdf?src-supplier=Verical; ComponentLink3Description: https://datasheet.ciiva.com/46440/1868787-46440891.pdf?src-supplier=Element14; ComponentLink3URL: https://datasheet.ciiva.com/46440/1868787-46440891.pdf?src-supplier=Element14; ComponentLink4Description: https://datasheet.ciiva.com/7983/443971-7983596.pdf?src-supplier=Element14; ComponentLink4URL: https://datasheet.ciiva.com/7983/443971-7983596.pdf?src-supplier=Element14; ComponentLink5Description: https://datasheet.ciiva.com/7746/1738695-7746239.pdf?src-supplier=Element14; ComponentLink5URL: https://datasheet.ciiva.com/7746/1738695-7746239.pdf?src-supplier=Element14; ComponentLink6Description: https://datasheet.ciiva.com/22747/443971-22747519.pdf?src-supplier=Element14; ComponentLink6URL: https://datasheet.ciiva.com/22747/443971-22747519.pdf?src-supplier=Element14; Construction: Rectangular; Depth: 0.8mm; Features: Moisture Resistant; Height: 0.45mm; Lead Free: Lead Free; Lead Pitch: 0.3mm; Length: 1.6mm; Library Name: UDEMY_Library.IntLib; Manufacturer Part Number: RC0603FR-0710KL; Max Operating Temperature: 155°C; Military Standard: Not; Min Operating Temperature: -55°C; Number of Pins: 2; Number of Terminations: 2; Packaging: Tape and Reel; Power Rating: 100mW; Pricing 1: 1=0,10000, 10=0,02300, 100=0,00930, 1000=0,00417, 2500=0,00362 (USD); REACH SVHC: Unknown; Resistor Type: Thick Film; RoHS: Yes; RoHS Compliant: Yes; Series: RC; Size Code: 0603; Stock 1: 24140601; Supplier 1: Digi-Key; Supplier Part Number 1: 311-10.0KHRCT-ND; Termination: SMD/SMT; Tolerance: 1%; Weight: 7.1E-05oz; Width: 0.8mm
RL1 RL2 = ALQ112: Power/Signal Relay, 1 Form C, SPDT, Momentary, 0.033A (Coil), 12VDC (Coil), 400mW (Coil), 5A (Contact), 30VDC (Contact), DC Input, Random, AC/DC Output, Through Hole-Straight Mount; Manufacturer: Panasonic; Body Breadth: 10mm; Body Height: 16mm; Body Length or Diameter: 20mm; Coil Current(DC)-Max: 33mA; Coil Operate Voltage(DC): 9V; Coil Release Voltage(DC): 600mV; Coil Resistance: 360R; Coil Voltage(DC)-Max: 12V; Contact AC Max Power Rating R Load: 1250VA@250VAC; Contact AC Max Rating R Load: 10A@125VAC; Contact Current(AC)-Max: 10A; Contact DC Max Power Rating R Load: 150W@30VDC; Contact DC Max Rating R Load: 5A@30VDC; Contact Resistance: 100mR; Contact Voltage(AC)-Max: 125V; Contact Voltage(DC)-Max: 30V; Designed by: ismet Akalın; Dielectric Strength Between Coil and Contacts: 4000Vrms; Dielectric Strength Between Open Contacts: 750Vrms; End Contact Material: Silver; Operate Time: 20ms; PCB Hole Count: 5; Reference Standard: UL; Relay Form: 1 FORM C; Sealing: MOISTURE PROOF; Insulation Resistance: 1GR; Case/Package: ALQ112; Category: Power Relays; Coil Power: 400mW; Coil Voltage-Nom: 12V; Coil/Input Supply Type: DC; Component Kind: Standard; ComponentLink1Description: https://datasheet.ciiva.com:443/pdfs/VipMasterIC/IC/PANA/PANA-S-A0003568054/PANA-S-A0003568054-1.pdf?src-supplier=IHS+Markit; ComponentLink1URL: https://datasheet.ciiva.com:443/pdfs/VipMasterIC/IC/PANA/PANA-S-A0003568054/PANA-S-A0003568054-1.pdf?src-supplier=IHS+Markit; ComponentLink2Description: https://datasheet.ciiva.com/24388/307ds-61b20-en-lq-24388909.pdf?src-supplier=Onlinecomponents.com; ComponentLink2URL: https://datasheet.ciiva.com/24388/307ds-61b20-en-lq-24388909.pdf?src-supplier=Onlinecomponents.com; Contact Current(DC)-Max: 5A; Contact/Output Supply Type: AC/DC; Manufacturer Part Number: ALQ112; Max Operating Temperature: 85°C; Min Operating Temperature: -40°C; Mounting Technology: Through Hole; Packaging: Box; Pins: 5; Pricing: 1=1,45, 10=1,267, 25=1,2004, 50=1,1528, 100=1,1067, 300=1,03757, 500=1,0069, 1000=0,96674, 5000=0,87955 (USD); Relay Action: MOMENTARY; Relay Function: SPDT; Relay Type: POWER/SIGNAL RELAY; Release Time: 20ms; RoHS: Yes; RoHS Compliant: Yes; Stock: 10844; Supplier: Digikey; Supplier Part Number: 255-3562-ND; Terminal Length: 0.0042inch; Termination: Solder; Weight: 7g; Input Switching Control Type: Random; Library Name: firstpcblib.IntLib
T1 = BV302S12010-ZU: 230-12 Transformer 1VA; Manufacturer: Zettler Magnetics; Designed by: ismet Akalın; Operating Frequency: 50-60Hz; Primary Voltage: 230V; Secondary Voltage: 12V; Component Kind: Standard; Manufacturer Part Number: BV302S12010-ZU; Rated Power: 1VA; RoHS: No; Supplier 1: Digi-Key; Library Name: firstpcblib.IntLib
U1 = LM2675MX-12/NOPB: Switching Regulator, Voltage-mode, 2.2A, 275kHz Switching Freq-Max, DMOS, PDSO8; Manufacturer: TI National Semiconductor; Channels: 1; Control Technique: PULSE WIDTH MODULATION; Designed by: ismet Akalın; Max Supply Voltage: 40V; Min Input Voltage: 6.5V; Nominal Output Voltage: 12V; Nominal Supply Voltage: 24V; Package Body Material: Plastic; Qualification Status: Not Qualified; Switcher Configuration: BUCK; Time @ Peak Reflow Temperature-Max (s): 40s; Case/Package: SOP; Category: Voltage Regulators - Switching; Component Kind: Standard; ComponentLink1Description: https://datasheet.ciiva.com:443/pdfs/VipMasterIC/IC/TXII/TXIIS100070/TXIIS168554-1.pdf?src-supplier=IHS+Markit; ComponentLink1URL: https://datasheet.ciiva.com:443/pdfs/VipMasterIC/IC/TXII/TXIIS100070/TXIIS168554-1.pdf?src-supplier=IHS+Markit; ComponentLink2Description: https://datasheet.ciiva.com/41457/001013881-da-01-en-ic-reg-buck-lm2675mx-12-nopb-soic-8-tid-41457896.pdf?src-supplier=Conrad; ComponentLink2URL: https://datasheet.ciiva.com/41457/001013881-da-01-en-ic-reg-buck-lm2675mx-12-nopb-soic-8-tid-41457896.pdf?src-supplier=Conrad; ComponentLink3Description: https://datasheet.ciiva.com/3220/lm2675-3220840.pdf?src-supplier=Avnet; ComponentLink3URL: https://datasheet.ciiva.com/3220/lm2675-3220840.pdf?src-supplier=Avnet; Control Mode: VOLTAGE-MODE; Frequency: 275kHz; Height - Seated (Max): 1.75mm; JESD-30 Code: R-PDSO-G8; JESD-609 Code: e3; Length: 4.9mm; Manufacturer Part Number: LM2675MX-12/NOPB; Max Operating Temperature: 125°C; Max Output current: 2.2A; Min Operating Temperature: -40°C; Moisture Sensitivity Level: 1; Package Shape: Rectangular; Package Style: SMALL OUTLINEMeter; Peak Reflow Temperature (Cel): 260°C; Pins: 8; Pricing: 1=4,36, 10=3,31, 25=3,046, 100=2,7561, 250=2,61788, 500=2,53454, 1000=2,46595, 2500=2,39355 (USD); Reach SVHC Compliant: Yes; RoHS: Yes; RoHS Compliant: Yes; Stock: 2174; Supplier: Digikey; Supplier Part Number: 296-35410-1-ND; Surface Mount: Yes; Technology: DMOS; Temperature Grade: AUTOMOTIVE; Terminal Finish: Tin; Terminal Form: Gull Wing; Terminal Pitch: 1.27mm; Terminal Position: DUAL; Throw Configuration: SWITCHING REGULATOR; Width: 3.9mm; Library Name: firstpcblib.IntLib
U2 = AP7361-33E-13: Fixed Positive LDO Regulator, 3.3V, 0.7V Dropout, CMOS, PSSO3; Manufacturer: Diodes; Channels: 1; Designed by: ismet Akalın; Dropout Voltage1-Max: 700mV; Dropout Voltage1-Nom: 500mV; Max Supply Voltage: 6V; Min Input Voltage: 4.3V; Output Current1-Max: 1A; Output Voltage1-Max: 3.399V; Output Voltage1-Min: 3.201V; Package Body Material: Plastic; Qualification Status: Not Qualified; Regulator Type: FIXED POSITIVE SINGLE OUTPUT LDO REGULATOR; Time @ Peak Reflow Temperature-Max (s): 30s; Voltage Tolerance-Max: 6.5%; Input Voltage Absolute-Max: 6.5V; Adjustability: FIXED; Case/Package: Sot-223; Category: Voltage Regulators - Linear; Component Kind: Standard; ComponentLink1Description: https://datasheet.ciiva.com:443/pdfs/VipMasterIC/IC/DIOD/DIOD-S-A0002906110/DIOD-S-A0002906110-1.pdf?src-supplier=IHS+Markit; ComponentLink1URL: https://datasheet.ciiva.com:443/pdfs/VipMasterIC/IC/DIOD/DIOD-S-A0002906110/DIOD-S-A0002906110-1.pdf?src-supplier=IHS+Markit; ComponentLink2Description: https://datasheet.ciiva.com/26926/getdatasheetpartid-670786-26926141.pdf?src-supplier=Verical; ComponentLink2URL: https://datasheet.ciiva.com/26926/getdatasheetpartid-670786-26926141.pdf?src-supplier=Verical; ComponentLink3Description: https://datasheet.ciiva.com/25651/ap7361-25651049.pdf?src-supplier=Digikey; ComponentLink3URL: https://datasheet.ciiva.com/25651/ap7361-25651049.pdf?src-supplier=Digikey; Height - Seated (Max): 1.8mm; JESD-609 Code: e3; Length: 6.5mm; Manufacturer Part Number: AP7361-33E-13; Moisture Sensitivity Level: 1; Number of Outputs: 1; Operating Temperature, TJ-Max: 150°C; Operating Temperature, TJ-Min: -40°C; Output Voltage1-Nom: 3.3V; Package Shape: Rectangular; Packaging: Tape and Reel; Peak Reflow Temperature (Cel): 260°C; Pins: 3; Pricing: 1=0,71, 10=0,426, 25=0,3508, 100=0,2659, 250=0,22428, 500=0,1986, 1000=0,17711 (USD); Reach SVHC Compliant: Yes; RoHS: Yes; RoHS Compliant: Yes; Stock: 106349; Supplier: Digikey; Supplier Part Number: AP7361-33E-13DICT-ND; Surface Mount: Yes; Technology: CMOS; Terminal Finish: Tin; Terminal Form: Gull Wing; Terminal Pitch: 2.3mm; Terminal Position: SINGLE; Width: 3.5mm; Library Name: firstpcblib.IntLib
USB1 = Micro B USB Connector: CONN RCPT USB2.0 MICRO B SMD R/A; Manufacturer: Amphenol FCI; Connector Type: USB,Receptacle; Current Rating: 1.8A; Designed by: BERK; Gender: Receptacle; Max Voltage Rating (AC): 100V; Number of Contacts: 5; Number of Ports: 1; Pitch: 0.65mm; Insulation Resistance: 1GR; Category: USB Connectors; Color: Black; Component Kind: Standard; ComponentLink1Description: https://datasheet.ciiva.com/26853/getdatasheetpartid-418041-26853891.pdf?src-supplier=Verical; ComponentLink1URL: https://datasheet.ciiva.com/26853/getdatasheetpartid-418041-26853891.pdf?src-supplier=Verical; ComponentLink2Description: https://datasheet.ciiva.com/851/10118192-851112.pdf?src-supplier=Digi-Key; ComponentLink2URL: https://datasheet.ciiva.com/851/10118192-851112.pdf?src-supplier=Digi-Key; ComponentLink3Description: https://datasheet.ciiva.com/4598/10118192ac-197249-4598796.pdf?src-supplier=Mouser; ComponentLink3URL: https://datasheet.ciiva.com/4598/10118192ac-197249-4598796.pdf?src-supplier=Mouser; Contact Material: Copper Alloy; Contact Plating: Gold; Depth: 5.57mm; Features: Shielded; Flammability Rating: UL94 V-0; Housing Material: Polymer; Lead Free: Lead Free; Length: 7.86mm; Library Name: UDEMY_Library.IntLib; Manufacturer Part Number: 10118192-0001LF; Max Operating Temperature: 85°C; Min Operating Temperature: -55°C; Mount: Surface Mount,Horizontal; Number of Terminals/Pins: 5; Orientation: Right Angle; Package Quantity: 1400; Packaging: Tape and Reel; Pricing 1: 1400=0,22558, 2800=0,20624, 7000=0,19335, 9800=0,18046, 14000=0,17402, 35000=0,17144 (USD); Radiation Hardening: No; REACH SVHC: No SVHC; RoHS: Yes; Stock 1: 158200; Supplier 1: Digi-Key; Supplier Part Number 1: 609-4613-2-ND; Termination: Solder; USB Standard: USB 2.0; Voltage Rating: 100V
